# Altium schematic sheet Control_Board.SchDoc
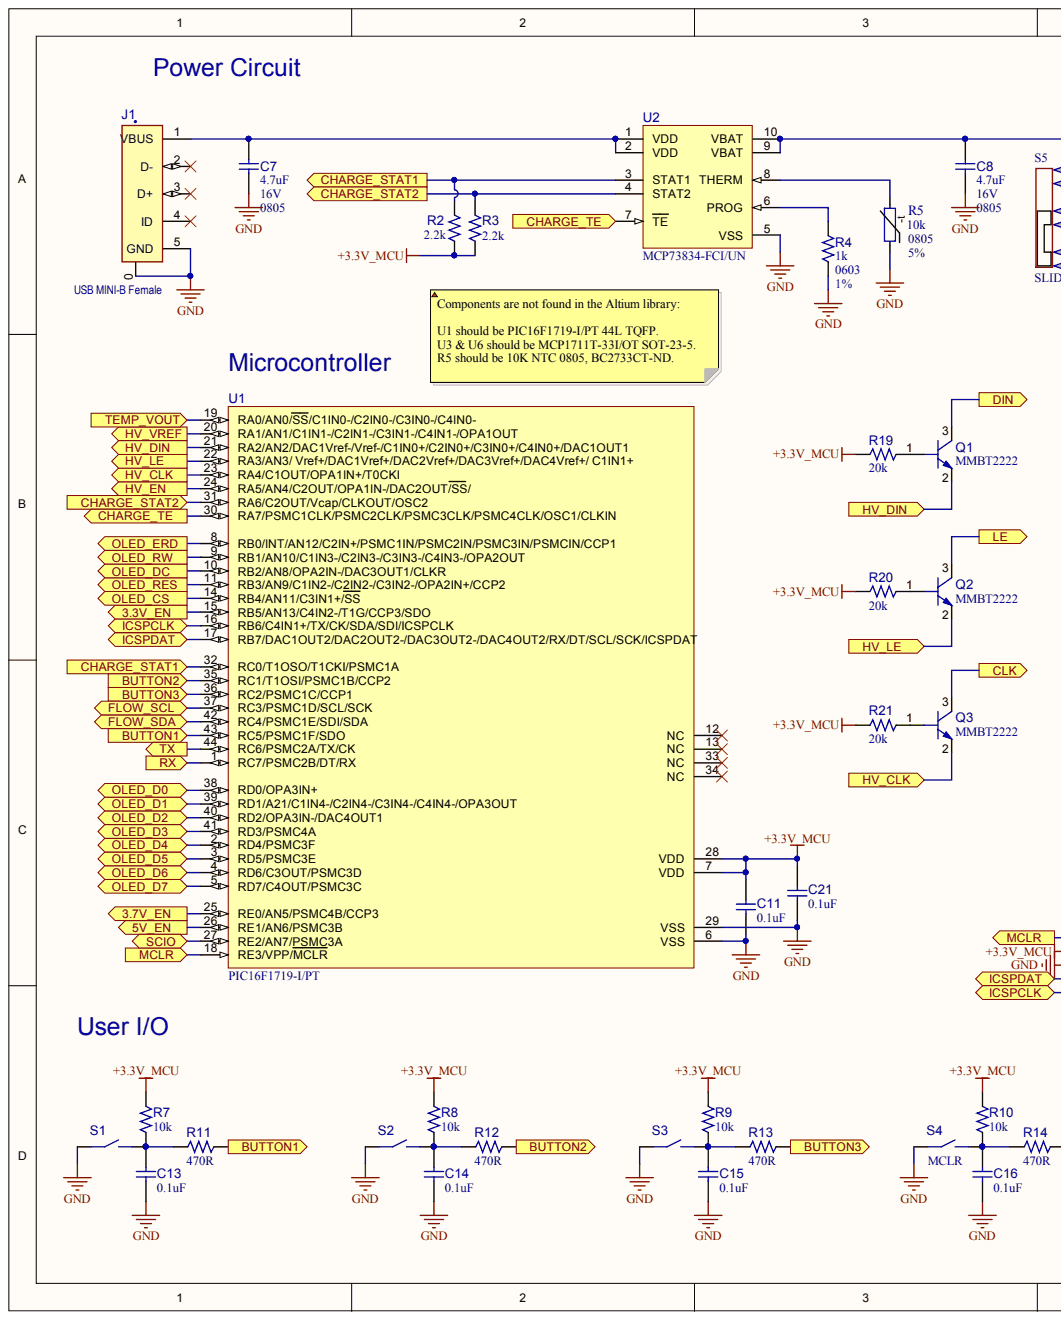
[diagram p0: Control_Board.SchDoc, left]
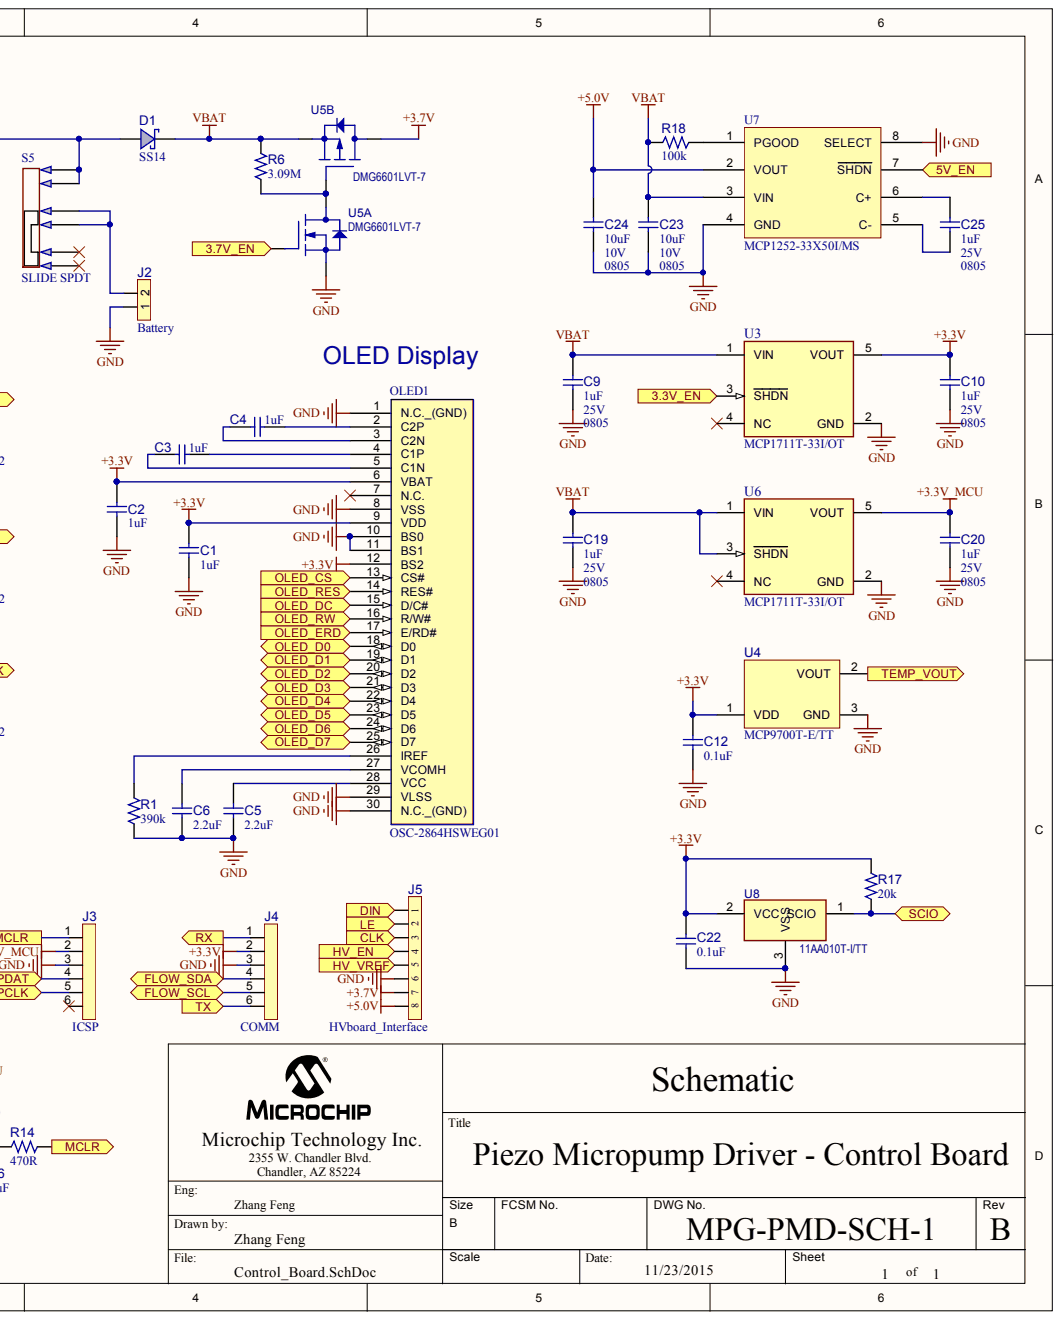
[diagram p1: Control_Board.SchDoc, right]

## Parts
C1  value 1uF  [CAP_C_0603]  (hidden comment: Capacitor NP)
C2  value 1uF  [CAP_C_0603]  (hidden comment: Capacitor NP)
C3  value 1uF  [CAP_C_0603]  (hidden comment: Capacitor NP)
C4  value 1uF  [CAP_C_0603]  (hidden comment: Capacitor NP)
C5  value 2.2uF  [CAP_C_0603]  (hidden comment: Capacitor NP)
C6  value 2.2uF  [CAP_C_0603]  (hidden comment: Capacitor NP)
C7  value 4.7uF  Package: 0805  Voltage: 16V  [CAP_C_0805]  (hidden comment: Capacitor NP)
C8  value 4.7uF  Package: 0805  Voltage: 16V  [CAP_C_0805]  (hidden comment: Capacitor NP)
C9  value 1uF  Package: 0805  Voltage: 25V  [CAP_C_0805]  (hidden comment: Capacitor NP)
C10  value 1uF  Package: 0805  Voltage: 25V  [CAP_C_0805]  (hidden comment: Capacitor NP)
C11  value 0.1uF  [CAP_C_0603]  (hidden comment: Capacitor NP)
C12  value 0.1uF  [CAP_C_0603]  (hidden comment: Capacitor NP)
C13  value 0.1uF  [CAP_C_0603]  (hidden comment: Capacitor NP)
C14  value 0.1uF  [CAP_C_0603]  (hidden comment: Capacitor NP)
C15  value 0.1uF  [CAP_C_0603]  (hidden comment: Capacitor NP)
C16  value 0.1uF  [CAP_C_0603]  (hidden comment: Capacitor NP)
C19  value 1uF  Package: 0805  Voltage: 25V  [CAP_C_0805]  (hidden comment: Capacitor NP)
C20  value 1uF  Package: 0805  Voltage: 25V  [CAP_C_0805]  (hidden comment: Capacitor NP)
C21  value 0.1uF  [CAP_C_0603]  (hidden comment: Capacitor NP)
C22  value 0.1uF  [CAP_C_0603]  (hidden comment: Capacitor NP)
C23  value 10uF  Package: 0805  Voltage: 10V  [CAP_C_0805]  (hidden comment: Capacitor NP)
C24  value 10uF  Package: 0805  Voltage: 10V  [CAP_C_0805]  (hidden comment: Capacitor NP)
C25  value 1uF  Package: 0805  Voltage: 25V  [CAP_C_0805]  (hidden comment: Capacitor NP)
D1  value SS14  Manufacturer Part Number 1: SS14  [DO-214AC_SMA]  (hidden comment: Diode Schottky)
J1  value USB MINI-B Female  Type: USB  [CON_USB-B_Mini_SMD_RA_HIR_UX60-MB-5ST]  (hidden comment: USB_mini_B)
J2  Battery  [HDR_M_2_1X2_SMD_RA]
J3  ICSP  [HDR_M_2.54_1x6]
J4  COMM  [HDR_M_2.54_1x6]
J5  HVboard_Interface  [HDR_M_2.54_1x8_RA]
OLED1  value OSC-2864HSWEG01  Category: Display  Manufacturer Part Number 1: OSC-2864HSWEG01  Type: OLED  [OLED_2864HSWEG01]  (hidden comment: OLED_2864HSWEG01)
Q1  value MMBT2222  Manufacturer Part Number 1: MMBT2222  [SOT-23-3]  (hidden comment: Transistor NPN BEC)
Q2  value MMBT2222  Manufacturer Part Number 1: MMBT2222  [SOT-23-3]  (hidden comment: Transistor NPN BEC)
Q3  value MMBT2222  Manufacturer Part Number 1: MMBT2222  [SOT-23-3]  (hidden comment: Transistor NPN BEC)
R1  value 390k  [RES_C_0603]  (hidden comment: Resistor)
R2  value 2.2k  [RES_C_0603]  (hidden comment: Resistor)
R3  value 2.2k  [RES_C_0603]  (hidden comment: Resistor)
R4  value 1k  Package: 0603  Tolerance: 1%  [RES_C_0603]  (hidden comment: Resistor)
R5  10k  Package: 0805  Tolerance: 5%  [RES_C_0805]
R6  value 3.09M  [RES_C_0603]  (hidden comment: Resistor)
R7  value 10k  [RES_C_0603]  (hidden comment: Resistor)
R8  value 10k  [RES_C_0603]  (hidden comment: Resistor)
R9  value 10k  [RES_C_0603]  (hidden comment: Resistor)
R10  value 10k  [RES_C_0603]  (hidden comment: Resistor)
R11  value 470R  [RES_C_0603]  (hidden comment: Resistor)
R12  value 470R  [RES_C_0603]  (hidden comment: Resistor)
R13  value 470R  [RES_C_0603]  (hidden comment: Resistor)
R14  value 470R  [RES_C_0603]  (hidden comment: Resistor)
R17  value 20k  [RES_C_0603]  (hidden comment: Resistor)
R18  value 100k  [RES_C_0603]  (hidden comment: Resistor)
R19  value 20k  [RES_C_0603]  (hidden comment: Resistor)
R20  value 20k  [RES_C_0603]  (hidden comment: Resistor)
R21  value 20k  [RES_C_0603]  (hidden comment: Resistor)
S1  Switch 2 Pin  [SW_TACT_SMD_CK_KSR231GLFS]  (value not drawn)
S2  Switch 2 Pin  [SW_TACT_SMD_CK_KSR231GLFS]  (value not drawn)
S3  Switch 2 Pin  [SW_TACT_SMD_CK_KSR231GLFS]  (value not drawn)
S4  MCLR  [SW_TACT_SMD_CK_KSR231GLFS]
S5  value SLIDE SPDT  [SW_SLIDE_TH_ESW_EG1271]  (hidden comment: Switch 6 Pin SPDT)
U1  PIC16F1719-I/PT  [TQFP-44_10x10]
U2  MCP73834  Supplier Part Number 1: MCP73834-FCI/UN  [MSOP-10]
U3  MCP1711T-33I/OT  [SOT-23-5]
U4  MCP9700  Manufacturer Part Number 1: MCP9700T-E/TT  [SOT-23-3]
U5  Manufacturer Part Number 1: DMG6601LVT-7  [TSOT26]  (hidden comment: MOSFET N/P-CH 30V 26TSOT)  (parts A,B)
U6  MCP1711T-33I/OT  [SOT-23-5]
U7  MCP1252  Manufacturer Part Number 1: MCP1252-33X50I/MS  [MSOP-8]
U8  11AA010  Manufacturer Part Number 1: 11AA010T-I/TT  [SOT-23-3]

## Ports
3.3V_EN, 3.7V_EN, 5V_EN, BUTTON1, BUTTON2, BUTTON3, CHARGE_STAT1, CHARGE_STAT2, CHARGE_TE, CLK, DIN, FLOW_SCL, FLOW_SDA, HV_CLK, HV_DIN, HV_EN, HV_LE, HV_VREF, ICSPCLK, ICSPDAT, LE, MCLR, OLED_CS, OLED_D0, OLED_D1, OLED_D2, OLED_D3, OLED_D4, OLED_D5, OLED_D6, OLED_D7, OLED_DC, OLED_ERD, OLED_RES, OLED_RW, RX, SCIO, TEMP_VOUT, TX

## Nets
+3.3V: C1.2 C2.2 C10.2 C12.2 C22.2 J4.2 OLED1.6(VBAT) OLED1.9(VDD) OLED1.12(BS2) R17.2 U3.5(VOUT) U4.1 U8.2
+3.3V_MCU: C11.2 C20.2 C21.2 J3.2 R2.1 R3.1 R7.2 R8.2 R9.2 R10.2 R19.1 R20.1 R21.1 U1.7(VDD) U1.28(VDD) U6.5(VOUT)
+3.7V: J5.7 U5.4
+5.0V: C24.2 J5.8 U7.2(VOUT)
GND: C1.1 C2.1 C5.1 C6.1 C7.1 C8.1 C9.1 C10.1 C11.1 C12.1 C13.1 C14.1 C15.1 C16.1 C19.1 C20.1 C21.1 C22.1 C23.1 C24.1 J1.0 J1.5(GND) J2.1 J3.3 J4.3 J5.6 OLED1.1(N.C._(GND)) OLED1.8(VSS) OLED1.10(BS0) OLED1.11(BS1) OLED1.29(VLSS) OLED1.30(N.C._(GND)) R1.1 R4.1 R5.1 S1.1 S2.1 S3.1 S4.1 U1.6(VSS) U1.29(VSS) U2.5(VSS) U3.2(GND) U4.3 U5.5 U6.2(GND) U7.4(GND) U7.8(SELECT) U8.3
VBAT: C9.2 C19.2 C23.2 D1.2 R6.2 R18.1 U3.1(VIN) U5.2 U6.1(VIN) U6.3(SHDN) U7.3(VIN)
3.3V_EN: U1.15(RB5/AN13/C4IN2-/T1G/CCP3/SDO) U3.3(SHDN) ; port 3.3V_EN
3.7V_EN: U1.25(RE0/AN5/PSMC4B/CCP3) U5.1 ; port 3.7V_EN
5V_EN: U1.26(RE1/AN6/PSMC3B) U7.7(SHDN) ; port 5V_EN
BUTTON1: R11.2 U1.43(RC5/PSMC1F/SDO) ; port BUTTON1
BUTTON2: R12.2 U1.35(RC1/T1OSI/PSMC1B/CCP2) ; port BUTTON2
BUTTON3: R13.2 U1.36(RC2/PSMC1C/CCP1) ; port BUTTON3
CHARGE_STAT1: R2.2 U1.32(RC0/T1OSO/T1CKI/PSMC1A) U2.3(STAT1) ; port CHARGE_STAT1
CHARGE_STAT2: R3.2 U1.31(RA6/C2OUT/Vcap/CLKOUT/OSC2) U2.4(STAT2) ; port CHARGE_STAT2
CHARGE_TE: U1.30(RA7/PSMC1CLK/PSMC2CLK/PSMC3CLK/PSMC4CLK/OSC1/CLKIN) U2.7(TE) ; port CHARGE_TE
CLK: J5.3 Q3.3 ; port CLK
DIN: J5.1 Q1.3 ; port DIN
FLOW_SCL: J4.5 U1.37(RC3/PSMC1D/SCL/SCK) ; port FLOW_SCL
FLOW_SDA: J4.4 U1.42(RC4/PSMC1E/SDI/SDA) ; port FLOW_SDA
HV_CLK: Q3.2 U1.23(RA4/C1OUT/OPA1IN+/T0CKI) ; port HV_CLK
HV_DIN: Q1.2 U1.21(RA2/AN2/DAC1Vref-/Vref-/C1IN0+/C2IN0+/C3IN0+/C4IN0+/DAC1OUT1) ; port HV_DIN
HV_EN: J5.4 U1.24(RA5/AN4/C2OUT/OPA1IN-/DAC2OUT/SS/) ; port HV_EN
HV_LE: Q2.2 U1.22(RA3/AN3/_Vref+/DAC1Vref+/DAC2Vref+/DAC3Vref+/DAC4Vref+/_C1IN1+) ; port HV_LE
HV_VREF: J5.5 U1.20(RA1/AN1/C1IN1-/C2IN1-/C3IN1-/C4IN1-/OPA1OUT) ; port HV_VREF
ICSPCLK: J3.5 U1.16(RB6/C4IN1+/TX/CK/SDA/SDI/ICSPCLK) ; port ICSPCLK
ICSPDAT: J3.4 U1.17(RB7/DAC1OUT2/DAC2OUT2-/DAC3OUT2-/DAC4OUT2/RX/DT/SCL/SCK/ICSPDAT) ; port ICSPDAT
LE: J5.2 Q2.3 ; port LE
MCLR: J3.1 R14.2 U1.18(RE3/VPP/MCLR) ; port MCLR
OLED_CS: OLED1.13(CS#) U1.14(RB4/AN11/C3IN1+/SS) ; port OLED_CS
OLED_D0: OLED1.18(D0) U1.38(RD0/OPA3IN+) ; port OLED_D0
OLED_D1: OLED1.19(D1) U1.39(RD1/A21/C1IN4-/C2IN4-/C3IN4-/C4IN4-/OPA3OUT) ; port OLED_D1
OLED_D2: OLED1.20(D2) U1.40(RD2/OPA3IN-/DAC4OUT1) ; port OLED_D2
OLED_D3: OLED1.21(D3) U1.41(RD3/PSMC4A) ; port OLED_D3
OLED_D4: OLED1.22(D4) U1.2(RD4/PSMC3F) ; port OLED_D4
OLED_D5: OLED1.23(D5) U1.3(RD5/PSMC3E) ; port OLED_D5
OLED_D6: OLED1.24(D6) U1.4(RD6/C3OUT/PSMC3D) ; port OLED_D6
OLED_D7: OLED1.25(D7) U1.5(RD7/C4OUT/PSMC3C) ; port OLED_D7
OLED_DC: OLED1.15(D/C#) U1.10(RB2/AN8/OPA2IN-/DAC3OUT1/CLKR) ; port OLED_DC
OLED_ERD: OLED1.17(E/RD#) U1.8(RB0/INT/AN12/C2IN+/PSMC1IN/PSMC2IN/PSMC3IN/PSMCIN/CCP1) ; port OLED_ERD
OLED_RES: OLED1.14(RES#) U1.11(RB3/AN9/C1IN2-/C2IN2-/C3IN2-/OPA2IN+/CCP2) ; port OLED_RES
OLED_RW: OLED1.16(R/W#) U1.9(RB1/AN10/C1IN3-/C2IN3-/C3IN3-/C4IN3-/OPA2OUT) ; port OLED_RW
RX: J4.1 U1.1(RC7/PSMC2B/DT/RX) ; port RX
SCIO: R17.1 U1.27(RE2/AN7/PSMC3A) U8.1 ; port SCIO
TEMP_VOUT: U1.19(RA0/AN0/SS/C1IN0-/C2IN0-/C3IN0-/C4IN0-) U4.2 ; port TEMP_VOUT
TX: J4.6 U1.44(RC6/PSMC2A/TX/CK) ; port TX
NetC3_1: C3.1 OLED1.5(C1N)
NetC3_2: C3.2 OLED1.4(C1P)
NetC4_1: C4.1 OLED1.3(C2N)
NetC4_2: C4.2 OLED1.2(C2P)
NetC5_2: C5.2 OLED1.28(VCC)
NetC6_2: C6.2 OLED1.27(VCOMH)
NetC7_2: C7.2 J1.1(VBUS) U2.1(VDD) U2.2(VDD)
NetC8_2: C8.2 D1.1 S5.3 S5.6 U2.9(VBAT) U2.10(VBAT)
NetC13_2: C13.2 R7.1 R11.1 S1.2
NetC14_2: C14.2 R8.1 R12.1 S2.2
NetC15_2: C15.2 R9.1 R13.1 S3.2
NetC16_2: C16.2 R10.1 R14.1 S4.2
NetC25_1: C25.1 U7.5(C-)
NetC25_2: C25.2 U7.6(C+)
NetJ2_2: J2.2 S5.2 S5.5
NetOLED1_26: OLED1.26(IREF) R1.2
NetQ1_1: Q1.1 R19.2
NetQ2_1: Q2.1 R20.2
NetQ3_1: Q3.1 R21.2
NetR4_2: R4.2 U2.6(PROG)
NetR5_2: R5.2 U2.8(THERM)
NetR6_1: R6.1 U5.3 U5.6
NetR18_2: R18.2 U7.1(PGOOD)

Not connected: J1 2(D-) 3(D+) 4(ID); J3 6; OLED1 7(N.C.); S5 1 4; U1 12(NC) 13(NC) 33(NC) 34(NC); U3 4(NC); U6 4(NC)

## Library data of the parts
C1-C4 = CAP0517: Capacitor; Manufacturer 1: Taiyo Yuden; Manufacturer Part Number 1: EMK107B7105KA-T; Description_: CAP CER 1uF 16V 10% X7R SMD 0603; Populated: Yes; Temperature Coeffcient: X7R; Tolerance: 10%; Type: Ceramic; Voltage: 16V; Category: Capacitor; Component Kind: Standard; ComponentLink1Description: Datasheet; ComponentLink1URL: https://chn-vm-apps.microchip.com/mchprepo/parts/Datasheets/mlcc01_e.pdf; Database Table Name: Capacitor; Library Name: MCHP-CDB.DbLib; Manufacturer 2: TDK; Manufacturer Part Number 2: C1608X7R1C105K; MCHP-ID: CAP0517; Operating Temperature: -55C to +125C; Package: 0603; Quantity Override: 1; Revision: 1; Status: Production; Supplier 1: Digi-Key; Supplier 2: Digi-Key; Supplier Part Number 1: 587-1241-1-ND; Supplier Part Number 2: 445-1604-1-ND
C5 C6 = CAP0597: Capacitor; Manufacturer 1: TDK; Manufacturer Part Number 1: C1608X5R1C225K; Description_: CAP CER 2.2uF 16V 10% X5R SMD 0603; Populated: Yes; Temperature Coeffcient: X5R; Tolerance: 10%; Type: Ceramic; Voltage: 16V; Category: Capacitor; Component Kind: Standard; ComponentLink1Description: Datasheet; ComponentLink1URL: https://chn-vm-apps.microchip.com/mchprepo/parts/Datasheets/general_B11.pdf; Database Table Name: Capacitor; Library Name: MCHP-CDB.DbLib; MCHP-ID: CAP0597; Operating Temperature: -55C to +85C; Package: 0603; Quantity Override: 1; Revision: 2; Status: Production; Supplier 1: Digi-Key; Supplier Part Number 1: 445-5157-1-ND
C7 C8 = CAP0419: Capacitor; Manufacturer 1: Panasonic; Manufacturer Part Number 1: ECJ-2FF1C475Z; Description_: CAP CER 4.7uF 16V 20% Y5V SMD 0805; Populated: Yes; Temperature Coeffcient: Y5V; Tolerance: 20%; Type: Ceramic; Category: Capacitor; Component Kind: Standard; ComponentLink1Description: Datasheet; ComponentLink1URL: https://chn-vm-apps.microchip.com/mchprepo/parts/Datasheets/ECJ__General_.pdf; Database Table Name: Capacitor; Library Name: MCHP-CDB.DbLib; MCHP-ID: CAP0419; Operating Temperature: -30C to +85C; Quantity Override: 1; Revision: 1; Status: Production; Supplier 1: Digi-Key; Supplier Part Number 1: PCC2232TR-ND
C9 C10 C19 C20 C25 = CAP1211: Capacitor; Manufacturer 1: AVX; Manufacturer Part Number 1: 08053C105K4Z2A; Description_: CAP CER 1uF 25V 10% X7R SMD 0805; Owner: Tad Ahlvers; Populated: YES; Temperature Coeffcient: X7R; Tolerance: 10%; Type: Ceramic; Category: Capacitor; Component Kind: Standard; ComponentLink1Description: Datasheet; ComponentLink1URL: https://chn-vm-apps.microchip.com/mchprepo/parts/Datasheets/flexiterm.pdf; Database Table Name: Capacitor; Library Name: MCHP-CDB.DbLib; Manufacturer 2: KEMET; Manufacturer 3: TDK Corporation; Manufacturer Part Number 2: C0805C105K3RACTU; Manufacturer Part Number 3: C2012X7R1E105K125AB; MCHP-ID: CAP1211; Operating Temperature: -55C to +125C; Quantity Override: 1; Revision: 1; Status: MCL Design; Supplier 1: Digi-Key; Supplier 2: Digi-Key; Supplier 3: Digi-Key; Supplier Part Number 1: 478-6357-2-ND; Supplier Part Number 2: C0805C105K3RACTU-ND; Supplier Part Number 3: 445-1354-1-ND
C11-C16 C21 C22 = CAP0011: Capacitor; Manufacturer 1: AVX; Manufacturer Part Number 1: 0603YC104KAT2A; Description_: CAP CER 0.1uF 16V 10% X7R SMD 0603; Populated: Yes; Temperature Coeffcient: X7R; Tolerance: 10%; Type: Ceramic; Voltage: 16V; Category: Capacitor; Component Kind: Standard; ComponentLink1Description: Datasheet; ComponentLink1URL: https://chn-vm-apps.microchip.com/mchprepo/parts/Datasheets/nmc2.pdf; Database Table Name: Capacitor; Library Name: MCHP-CDB.DbLib; Manufacturer 2: Murata; Manufacturer 3: Panasonic; Manufacturer 4: Taiyo Yuden; Manufacturer 5: Yageo; Manufacturer 6: NIC Components; Manufacturer Part Number 2: GRM188R71C104KA01D; Manufacturer Part Number 3: ECJ-1VB1C104K; Manufacturer Part Number 4: EMK107B7104KA-T; Manufacturer Part Number 5: CC0603KRX7R7BB104; Manufacturer Part Number 6: NMC0603X7R104K16TRPF; MCHP-ID: CAP0011; Operating Temperature: -55C to +125C; Package: 0603; Quantity Override: 1; Revision: 3; Status: Production; Supplier 1: Digi-Key; Supplier 2: Digi-Key; Supplier 3: Digi-Key; Supplier 4: Digi-Key; Supplier 5: Newark; Supplier 6: Digi-Key; Supplier 7: NIC Components; Supplier Part Number 1: 478-1239-1-ND; Supplier Part Number 2: 490-1532-1-ND; Supplier Part Number 3: PCC1762CT-ND; Supplier Part Number 4: 587-1240-2-ND; Supplier Part Number 5: 86H5248; Supplier Part Number 6: 311-1088-1-ND; Supplier Part Number 7: NMC0603X7R104K16TRPF
C23 C24 = CAP1148: Capacitor; Manufacturer 1: TDK Corporation; Manufacturer Part Number 1: C2012X7R1A106K125AC; Description_: CAP CER 10uF 10V 10% X7R SMD 0805; Dev Tools Status: Production; Owner: Mihai T(M91442); Populated: Yes; Temperature Coeffcient: X7R; Tolerance: 10%; Type: Ceramic; Category: Capacitor; Component Kind: Standard; ComponentLink1Description: Datasheet; ComponentLink1URL: https://chn-vm-apps.microchip.com/mchprepo/parts/Datasheets/L0005E.pdf; Database Table Name: Capacitor; Library Name: MCHP-CDB.DbLib; Manufacturer 2: Murata; Manufacturer Part Number 2: GRM21BR71A106KE51L; MCHP-ID: CAP1148; Operating Temperature: -55C to +125C; Quantity Override: 1; Revision: 0; Status: MCL Design; Supplier 1: Digi-Key; Supplier 2: Digi-Key; Supplier 3: Farnell; Supplier Part Number 1: 445-6857-1-ND; Supplier Part Number 2: 490-3905-1-ND; Supplier Part Number 3: 1828828
D1 = DIODE0128: Manufacturer 1: Fairchild; Description_: DIO SCTKY SS14 500mV 1A 40V DO-214AC_SMA; Forward Current: 1A; Populated: YES; Power: 1.1W; Reverse Current: 200uA; Reverse Voltage: 40V; Type: Schottky Single; Category: Diode; Component Kind: Standard; ComponentLink1Description: Datasheet; ComponentLink1URL: https://chn-vm-apps.microchip.com/mchprepo/parts/Datasheets/SS12.pdf; Database Table Name: Diode; Library Name: MCHP-CDB.DbLib; MCHP-ID: DIODE0128; Operating Temperature: -65C to +125C; Package: DO-214AC_SMA; Quantity Override: 1; Revision: 1; Status: Production; Supplier 1: Digi-Key; Supplier Part Number 1: SS14CT-ND
J1 = CON0276: Connector USB; Manufacturer 1: Hirose; Manufacturer Part Number 1: UX60-MB-5ST; Description_: CON USB MINI-B Female SMD R/A; Populated: Yes; Category: Connector; Component Kind: Standard; ComponentLink1Description: Datasheet; ComponentLink1URL: https://chn-vm-apps.microchip.com/mchprepo/parts/Datasheets/e24000019.pdf; Database Table Name: Connector; Library Name: MCHP-CDB.DbLib; Manufacturer 2: Oupiin; Manufacturer Part Number 2: 8969-B05C00DBU; MCHP-ID: CON0276; Quantity Override: 1; Revision: 2; Status: Production; Supplier 1: Digi-Key; Supplier 2: Digi-Key; Supplier 3: Oupiin; Supplier Part Number 1: H2959CT-ND; Supplier Part Number 2: H2959TR-ND; Supplier Part Number 3: 8969-B05C00DBU
J2 = CON1434: Manufacturer 1: JST Sales America Inc.; Manufacturer Part Number 1: S2B-PH-SM4-TB(LF)(SN); Database Table Name: Connector; Description_: CONN HDR-2 Male 1X2 SMD R/A; Dev Tools Status: Design; Owner: Jose Garcia; Populated: YES; Value: CONN HDR PH SMD 2MM; Category: Connector; Component Kind: Standard; ComponentLink1Description: Datasheet; ComponentLink1URL: https://chn-vm-apps.microchip.com/mchprepo/parts/Datasheets/ePH; Library Name: MCHP-CDB.DbLib; MCHP-ID: CON1434; Quantity Override: 1; Revision: 1; Status: MCL Design; Supplier 1: Digi-Key; Supplier Part Number 1: 455-1749-1-ND; Type: Header 2mm
J3 J4 = CON1106: Manufacturer 1: FCI; Manufacturer Part Number 1: 68001-106HLF; Database Table Name: Connector; Description_: CON HDR-2.54 Male 1x6 Gold 5.84MH TH VERT; Owner: Bogdan P (M91398); Populated: Yes; Value: HDR-2.54 Male 1x6; Category: Connector; Component Kind: Standard; ComponentLink1Description: Datasheet; ComponentLink1URL: https://chn-vm-apps.microchip.com/mchprepo/parts/Datasheets/68000.pdf; Library Name: MCHP-CDB.DbLib; MCHP-ID: CON1106; Quantity Override: 1; Revision: 0; Status: Production; Supplier 1: Digi-Key; Supplier Part Number 1: 609-3272-ND; Type: Header 2.54mm
J5 = CON1290: Manufacturer 1: Sullins Connector Solutions; Manufacturer Part Number 1: PBC08SBAN; Database Table Name: Connector; Description_: CON HDR-2.54 Male 1x8 Gold 5.84MH TH R/A; Owner: Bogdan Popescu; Populated: YES; Value: HDR-2.54 Male 1x8; Category: Connector; Component Kind: Standard; ComponentLink1Description: Datasheet; ComponentLink1URL: https://chn-vm-apps.microchip.com/mchprepo/parts/Datasheets/97_Page78-79_HEADER_.100_2.54mm_MALE_BREAKAWAY.pdf; Library Name: MCHP-CDB.DbLib; MCHP-ID: CON1290; Quantity Override: 1; Revision: A; Status: Design; Supplier 1: Digi-Key; Supplier Part Number 1: S1111E-08-ND; Type: Header 2.54mm
OLED1 = LCD0034: Manufacturer 1: OSD Displays; Description_: DISPLAY OLED OSC-2864HSWEG01 MNT; Owner: Mike Sullivan (Arena); Populated: YES; Component Kind: Standard; ComponentLink1Description: Datasheet; ComponentLink1URL: https://chn-vm-apps.microchip.com/mchprepo/parts/Datasheets/OSD-2864HSWEG01.pdf; Database Table Name: Display; Library Name: MCHP-CDB.DbLib; MCHP-ID: LCD0034; Operating Temperature: -30C to +70C; Quantity Override: 1; Revision: 0; Status: Design; Supplier 1: OSD Displays; Supplier Part Number 1: OSC-2864HSWEG01
Q1-Q3 = TRA0039: Transistor Bipolar; Manufacturer 1: Fairchild; Current: 600mA; Description_: TRANS BJT NPN MMBT2222 30V 600mA 350mW SOT-23-3; Dev Tools Status: Production; Populated: YES; Power: 350mW; Type: BJT; Voltage: 30V; Category: Transistor; Component Kind: Standard; ComponentLink1Description: Datasheet; ComponentLink1URL: https://chn-vm-apps.microchip.com/mchprepo/parts/Datasheets/MMBT2222.pdf; Database Table Name: Transistor; Library Name: MCHP-CDB.DbLib; MCHP-ID: TRA0039; Operating Temperature: -55C to +150C; Package: SOT-23-3; Quantity Override: 1; Revision: 2; Status: MCL Design; Supplier 1: Fairchild; Supplier Part Number 1: MMBT2222
R1 = RSMT0758: Manufacturer 1: Panasonic; Manufacturer Part Number 1: ERJ-3EKF3903V; Description_: RES TKF 390k 1% 1/10W SMD 0603; Populated: Yes; Power: 1/10W; Temperature Coeffcient: 100ppm/C; Tolerance: 1%; Voltage: 75V; Category: Resistor; Component Kind: Standard; ComponentLink1Description: Datasheet; ComponentLink1URL: https://chn-vm-apps.microchip.com/mchprepo/parts/Datasheets/AOA0000CE2.pdf; Composition: TKF; Database Table Name: Resistor; Description: Resistor; Library Name: MCHP-CDB.DbLib; MCHP-ID: RSMT0758; Operating Temperature: -55C to +155C; Package: 0603; Quantity Override: 1; Revision: 1; Status: Production; Supplier 1: Digi-Key; Supplier Part Number 1: P390KHCT-ND; Type: RES SMD
R2 R3 = RES1130: Manufacturer 1: Panasonic; Manufacturer Part Number 1: ERJ-3EKF2201V; Description_: RES TKF 2.2k 1% 1/10W SMD 0603; Owner: Bogdan P (M91398); Populated: Yes; Power: 1/10W; Temperature Coeffcient: 100ppm/C; Tolerance: 1%; Voltage: 75V; Category: Resistor; Component Kind: Standard; ComponentLink1Description: Datasheet; ComponentLink1URL: https://chn-vm-apps.microchip.com/mchprepo/parts/Datasheets/AOA0000CE2.pdf; Composition: TKF; Database Table Name: Resistor; Description: Resistor; Library Name: MCHP-CDB.DbLib; MCHP-ID: RES1130; Operating Temperature: -55C to +155C; Package: 0603; Quantity Override: 1; Revision: 0; Status: Production; Supplier 1: Digi-Key; Supplier Part Number 1: P2.20KHCT-ND; Type: RES SMD
R4 = RSMT0138: Manufacturer 1: Panasonic; Manufacturer Part Number 1: ERJ-3EKF1001V; Description_: RES TKF 1k 1% 1/10W SMD 0603; Populated: Yes; Power: 1/10W; Temperature Coeffcient: 100ppm/C; Voltage: 75V; Category: Resistor; Component Kind: Standard; ComponentLink1Description: Datasheet; ComponentLink1URL: https://chn-vm-apps.microchip.com/mchprepo/parts/Datasheets/AOA0000CE2.pdf; Composition: TKF; Database Table Name: Resistor; Description: Resistor; Library Name: MCHP-CDB.DbLib; Manufacturer 2: Yageo; Manufacturer 3: ROHM; Manufacturer 4: Stackpole Electronics Inc; Manufacturer 5: Stackpole Electronics Inc; Manufacturer 6: Vishay; Manufacturer 7: Stackpole Electronics Inc; Manufacturer Part Number 2: RC0603FR-071KL; Manufacturer Part Number 3: MCR03EZPFX1001; Manufacturer Part Number 4: RMCF0603FT1K00; Manufacturer Part Number 5: RMCF 1/16 1K 1% R; Manufacturer Part Number 6: CRCW06031K00FKEA; Manufacturer Part Number 7: RMCF1/161.0K1%TR; MCHP-ID: RSMT0138; Operating Temperature: -55C to +155C; Quantity Override: 1; Revision: 2; Status: Production; Supplier 1: Digi-Key; Supplier 2: Digi-Key; Supplier 3: Digi-Key; Supplier 4: Digi-Key; Supplier 5: Digi-Key; Supplier 6: Digi-Key; Supplier 7: Newark; Supplier 8: Stackpole Electronics Inc; Supplier Part Number 1: P1.00KHCT-ND; Supplier Part Number 2: P1.00KHTR-ND; Supplier Part Number 3: 311-1.00KHRCT-ND; Supplier Part Number 4: RHM1.00KHCT-ND; Supplier Part Number 5: RMCF1/161KFRTR-ND; Supplier Part Number 6: RMCF0603FT1K00TR-ND; Supplier Part Number 7: 52K8015; Supplier Part Number 8: RMCF1/161.0K1%TR; Type: RES SMD
R5 = RES1425: Manufacturer 1: Vishay BC Components; Manufacturer Part Number 1: NTCS0805E3473JHT; Circuit Type: Isolated; Database Table Name: Resistor; Description_: RES THERMISTOR 47K 5% 210mW SMD 0805; Owner: Stephen Humberd; Populated: YES; Power: 210mW; Temperature Coeffcient: NTC; Value: 47k; Category: Resistor; Component Kind: Standard; ComponentLink1Description: Datasheet; ComponentLink1URL: https://chn-vm-apps.microchip.com/mchprepo/parts/Datasheets/ntcs0805e3t.pdf; Composition: C; Library Name: MCHP-CDB.DbLib; MCHP-ID: RES1425; Operating Temperature: -40C to +150C; Quantity Override: 1; Revision: B; Status: Design; Supplier 1: Digi-Key; Supplier Part Number 1: BC2297CT-ND; Type: Thermistor
R6 = RSMT1115: Manufacturer 1: Vishay Dale; Manufacturer Part Number 1: CRCW06033M09FKEA; Description_: RES TKF 3.09M 1% 1/10W SMD 0603; Owner: Bogdan Popescu; Populated: YES; Power: 1/10W; Temperature Coeffcient: 100ppm/C; Tolerance: 1%; Voltage: 75V; Category: Resistor; Component Kind: Standard; ComponentLink1Description: Datasheet; ComponentLink1URL: https://chn-vm-apps.microchip.com/mchprepo/parts/Datasheets/dcrcwe3.pdf; Composition: TKF; Database Table Name: Resistor; Description: Resistor; Library Name: MCHP-CDB.DbLib; MCHP-ID: RSMT1115; Operating Temperature: -55C to +155C; Package: 0603; Quantity Override: 1; Revision: A; Status: Design; Supplier 1: Digi-Key; Supplier Part Number 1: 541-3.09MHCT-ND; Type: RES SMD
R7-R10 = RSMT0139: Manufacturer 1: Panasonic; Manufacturer Part Number 1: ERJ-3EKF1002V; Description_: RES TKF 10k 1% 1/10W SMD 0603; Populated: Yes; Power: 1/10W; Temperature Coeffcient: 100ppm/C; Tolerance: 1%; Category: Resistor; Component Kind: Standard; ComponentLink1Description: Datasheet; ComponentLink1URL: https://chn-vm-apps.microchip.com/mchprepo/parts/Datasheets/nrc.pdf; Composition: TKF; Database Table Name: Resistor; Description: Resistor; Library Name: MCHP-CDB.DbLib; Manufacturer 2: Stackpole Electronics Inc; Manufacturer 3: Yageo; Manufacturer 4: Stackpole Electronics Inc; Manufacturer 5: Xicon; Manufacturer 6: NIC Components; Manufacturer Part Number 2: RMCF0603FT10K0; Manufacturer Part Number 3: RC0603FR-0710KL; Manufacturer Part Number 4: RMCF 1/16 10K 1% R; Manufacturer Part Number 5: 302-10K-RC; Manufacturer Part Number 6: NRC06F1002TRF; MCHP-ID: RSMT0139; Operating Temperature: -55C to +155C; Package: 0603; Quantity Override: 1; Revision: 2; Status: Production; Supplier 1: Digi-Key; Supplier 2: Digi-Key; Supplier 3: Digi-Key; Supplier 4: Digi-Key; Supplier 5: Mouser; Supplier 6: NIC Components; Supplier Part Number 1: P10.0KHCT-ND; Supplier Part Number 2: RMCF1/1610KFRTR-ND; Supplier Part Number 3: 311-10.0KHRCT-ND; Supplier Part Number 4: RMCF0603FT10K0TR-ND; Supplier Part Number 5: 302-10k-RC; Supplier Part Number 6: NRC06F1002TRF; Type: RES SMD
R11-R14 = RSMT0518: Manufacturer 1: Yageo; Manufacturer Part Number 1: RC0603FR-07470RL; Description_: RES TKF 470R 1% 1/10W SMD 0603; Populated: Yes; Power: 1/10W; Temperature Coeffcient: 100ppm/C; Tolerance: 1%; Voltage: 50V; Category: Resistor; Component Kind: Standard; ComponentLink1Description: Datasheet; ComponentLink1URL: https://chn-vm-apps.microchip.com/mchprepo/parts/Datasheets/PYu-RC0603_51_RoHS_L_4.pdf; Composition: TKF; Database Table Name: Resistor; Description: Resistor; Library Name: MCHP-CDB.DbLib; Manufacturer 2: Panasonic; Manufacturer 3: Stackpole Electronics Inc; Manufacturer Part Number 2: ERJ-3EKF4700V; Manufacturer Part Number 3: RMCF0603FT470R; MCHP-ID: RSMT0518; Operating Temperature: -55C to +155C; Package: 0603; Quantity Override: 1; Revision: 1; Status: Production; Supplier 1: Digi-Key; Supplier 2: Digi-Key; Supplier 3: Digi-Key; Supplier Part Number 1: 311-470HRCT-ND; Supplier Part Number 2: P470HCT-ND; Supplier Part Number 3: RMCF1/16470FRTR-ND; Type: RES SMD
R17 = RSMT0309: Manufacturer 1: Yageo; Manufacturer Part Number 1: 9C06031A2002FKHFT; Description_: RES TKF 20k 1% 1/10W SMD 0603; Populated: Yes; Power: 1/10W; Temperature Coeffcient: 100ppm/C; Tolerance: 1%; Voltage: 50V; Category: Resistor; Component Kind: Standard; ComponentLink1Description: Datasheet; ComponentLink1URL: https://chn-vm-apps.microchip.com/mchprepo/parts/Datasheets/PYu-RC0603_51_RoHS_L_4.pdf; Composition: TKF; Database Table Name: Resistor; Description: Resistor; Library Name: MCHP-CDB.DbLib; Manufacturer 2: Yageo; Manufacturer 3: Yageo; Manufacturer 4: Stackpole Electronics Inc; Manufacturer 5: Panasonic; Manufacturer Part Number 2: RC0603FR-0720KL; Manufacturer Part Number 3: 9C06031A2002FKPFT; Manufacturer Part Number 4: RMCF1/1620.0K1%TR; Manufacturer Part Number 5: ERJ-3EKF2002V; MCHP-ID: RSMT0309; Operating Temperature: -55C to +155C; Package: 0603; Quantity Override: 1; Revision: 1; Status: Production; Supplier 1: Digi-Key; Supplier 2: Yageo; Supplier 3: Digi-Key; Supplier 4: Yageo; Supplier 5: Stackpole Electronics Inc; Supplier 6: Digi-Key; Supplier Part Number 1: 311-20.0KHTR-ND; Supplier Part Number 2: RC0603FR-0720KL; Supplier Part Number 3: 311-20.0KHRCT-ND; Supplier Part Number 4: 9C06031A2002FKPFT; Supplier Part Number 5: RMCF1/1620.0K1%TR; Supplier Part Number 6: P20.0KHCT-ND; Type: RES SMD
R18 = RES1066: Manufacturer 1: Vishay; Manufacturer Part Number 1: MCT06030C1003FP500; Description_: RES TF 100k 1% 1/8W SMD 0603; Owner: Tad Ahlvers; Populated: Yes; Power: 1/8W; Temperature Coeffcient: 50ppm/C; Tolerance: 1%; Voltage: 75V; Category: Resistor; Component Kind: Standard; ComponentLink1Description: Datasheet; ComponentLink1URL: https://chn-vm-apps.microchip.com/mchprepo/parts/Datasheets/mc_pro.pdf; Composition: TF; Database Table Name: Resistor; Description: Resistor; Library Name: MCHP-CDB.DbLib; MCHP-ID: RES1066; Operating Temperature: -55C to +155C; Package: 0603; Quantity Override: 1; Revision: 1; Status: Production; Supplier 1: Digi-Key; Supplier Part Number 1: MCT0603-100K-CFTR-ND; Type: RES SMD
R19-R21 = RSMT0309: Manufacturer 1: Panasonic; Manufacturer Part Number 1: ERJ-3EKF2002V; Description_: RES TKF 20k 1% 1/10W SMD 0603; Dev Tools Status: Production; Populated: Yes; Power: 1/10W; Temperature Coeffcient: 100ppm/C; Tolerance: 1%; Voltage: 50V; Category: Resistor; Component Kind: Standard; ComponentLink1Description: Datasheet; ComponentLink1URL: https://chn-vm-apps.microchip.com/mchprepo/parts/Datasheets/PYu-RC0603_51_RoHS_L_4.pdf; Composition: TKF; Database Table Name: Resistor; Description: Resistor; Library Name: MCHP-CDB.DbLib; Manufacturer 2: Yageo; Manufacturer 3: Yageo; Manufacturer 4: Stackpole Electronics Inc; Manufacturer 5: Yageo; Manufacturer Part Number 2: 9C06031A2002FKHFT; Manufacturer Part Number 3: RC0603FR-0720KL; Manufacturer Part Number 4: RMCF1/1620.0K1%TR; Manufacturer Part Number 5: 9C06031A2002FKPFT; MCHP-ID: RSMT0309; Operating Temperature: -55C to +155C; Package: 0603; Quantity Override: 1; Revision: 1; Status: MCL Design; Supplier 1: Digi-Key; Supplier 2: Digi-Key; Supplier 3: Digi-Key; Supplier 4: Yageo; Supplier 5: Stackpole Electronics Inc; Supplier 6: Yageo; Supplier Part Number 1: P20.0KHCT-ND; Supplier Part Number 2: 311-20.0KHTR-ND; Supplier Part Number 3: 311-20.0KHRCT-ND; Supplier Part Number 4: RC0603FR-0720KL; Supplier Part Number 5: RMCF1/1620.0K1%TR; Supplier Part Number 6: 9C06031A2002FKPFT; Type: RES SMD
S1-S4 = SWITCH1040: Switch; Manufacturer 1: TE Connectivity / Alcoswitch; Manufacturer Part Number 1: 147873-1; Current: 50mA; Description_: SWITCH TACT SPST 24V 50mA 147873-1 SMD; Owner: Stephen Humberd; Populated: YES; Value: 147873-1; Voltage: 24V; Category: Switch; Component Kind: Standard; ComponentLink1Description: Datasheet; ComponentLink1URL: https://chn-vm-apps.microchip.com/mchprepo/parts/Datasheets/NG_CD_147873_K3-196492.pdf; Database Table Name: Switch; Library Name: MCHP-CDB.DbLib; MCHP-ID: SWITCH1040; Quantity Override: 1; Revision: 1; Status: Production; Supplier 1: Mouser; Supplier Part Number 1: 506-147873-1; Type: Pushbutton
S5 = SWITCH0051: Switch, Slide, SPDT, TH; Manufacturer 1: E-Switch; Manufacturer Part Number 1: EG1271; Current: 300mA; Description_: SWITCH SLIDE DPDT 30V 300mA EG1271 TH; Dev Tools Status: Production; Populated: YES; Voltage: 30V; Category: Switch; Component Kind: Standard; ComponentLink1Description: Datasheet; ComponentLink1URL: https://chn-vm-apps.microchip.com/mchprepo/parts/Datasheets/EG.pdf; Database Table Name: Switch; Library Name: MCHP-CDB.DbLib; MCHP-ID: SWITCH0051; Operating Temperature: -20C to +70C; Quantity Override: 1; Revision: 1; Status: MCL Design; Supplier 1: Digi-Key; Supplier Part Number 1: EG1918-ND; Type: Slide
U1 = MIC6241: PIC16F; Manufacturer 1: Microchip Technology; Manufacturer Part Number 1: PIC16F1789-I/PT; ADCBit: 12; ADC Channels: 14; CAN: 0; Comparators: 4; Database Table Name: MCHP-Microcontroller; Description_: MCHP MCU 8-BIT 28K 2K PIC16F1789-I/PT 44TQFP; DigitalTimerQty_8bit: 2; DigitalTimerQty_16bit: 1; EEPROM: 256; ForceMinOrderQtyMult: True; IOPins: 36; LeadContent: Pb-FREE; LeadCount: 44; MAPS Description: 44-pin, 28KB Flash, 2KB RAM, 256 EEPROM, 12-bit DAC, 5-bit DAC, PSMC, OPAmp,; MaxSpeed: 32; MinOrderQty: 160; MPC: LECM17T4XAXX; OscillatorMHz: 32 MHz, 32 kHz; Owner: Jamus Griego; PackageWidth: 10x10x1mm; PackingMedia: TRAY; Populated: YES; Program Memory KBytes: 28; Program Memory KWords: 16; Ram: 2048; Stage: REL; TempRange: -40C to +85C; Value: PIC16F1789-I/PT; CapTouchCH: 14; Category: MCHP-Microcontroller; Component Kind: Standard; ComponentLink1Description: Datasheet; ComponentLink1URL: http://www.microchip.com/wwwproducts/Devices.aspx?product=PIC16F1789; DAChannels: 4; DigitalTimerQty_32bit: 0; Family: Enhanced Mid-Range; I2C: 1; Input Capture: 0; IntroductionDate: 2013-04-04; Library Name: MCHP-CDB.DbLib; MCHP-ID: MIC6241; mtrlcntrlpwmchannels: 14; PackageType: TQFP; PWM Channels: 0; QEI Channels: 0; Quantity Override: 1; Revision: B; SegmentLCD: 0; SMPS PWM Channels: 14; SPI: 1; Status: Design; Supplier 1: Digi-Key; Supplier Part Number 1: PIC16F1789-I/PT-ND; Type: 8-Bit; USART: 1; USBChannels: 0
U2 = MIC3178: MCP738XX; Manufacturer 1: Microchip; Manufacturer Part Number 1: MCP73833-FCI/UN; AutomaticRecharge: Yes; Database Table Name: MCHP-Analog; Description_: MCHP ANALOG BATTERY CHARGER MCP73833T-FCI/UN MSOP-10; ForceMinOrderQtyMult: False; IoutMax: 1200; LeadContent: Pb-FREE; LeadCount: 10; MAPS Description: 1A ADVANCED SINGLE CELL, FULLY INTEGRATED LI-ION POLYMER CHANGE MANAGEMENT CONTR; MinOrderQty: 5; MPC: DECJ27E3XAFC; NumberofLiIonCells: 1; OperatingCurrentTyp: 2; PackageWidth: 3x3mm; PackingMedia: TUBE; PassTransistor: INT; ReverseDischargeCurrentTyp: 0.200000002980232; Stage: REL; tblDeviceFamilyID: 1704; TempRange: -40C to +85C; UVLO: 3.5; Value: MCP73833; VccRangeMax: 6; VccRangeMin: 3.75; VolRegAccuracy: 0.75; VoltageRegulationTyp: 4.2, 4.35, 4.4, 4.5; Category: MCHP-Analog; ChargeMonitor: Yes; ChargeSafetyTimers: Yes; ChargeTermination: Yes; Component Kind: Standard; ComponentLink1Description: Datasheet; ComponentLink1URL: http://www.microchip.com/wwwproducts/Devices.aspx?product=MCP73833; IntroductionDate: 2006-09-05; Library Name: MCHP-CDB.DbLib; Manufacturer 2: Microchip; Manufacturer Part Number 2: MCP73833T-FCI/UN; MaxThermalRegulation: Yes; MCHP-ID: MIC3178; PackageType: MSOP; Populated: Yes; PreconditionCharge: Yes; Quantity Override: 1; Revision: 0; ShutdownMode: Yes; Status: Production; StatusOutput: Yes; Supplier 1: Microchip; Supplier 2: Microchip; Supplier Part Number 2: MCP73833T-FCI/UN; SupplyPresent: Yes; Technology: Linear; TempMonitor: Yes; Type: Battery Charger
U3 U6 = MIC6434: LDO MCP1711; Manufacturer 1: Microchip Technology; Manufacturer Part Number 1: MCP1711T-18I/OT; Accuracy: 1; Adjustable: No; Comments: New device; Database Table Name: MCHP-Analog; Description_: MCHP ANALOG LDO 1.8V MCP1711T-18I/OT SOT-23-5; Dropoutvoltage: 500; ForceMinOrderQtyMult: True; LeadContent: Pb-FREE; LeadCount: 5; MAPS Description: 600 nA Low Quiescent Current LDO; MaxInputVoltage: 6; MinInputVoltage: 1.4; MPC: YZ026TC7XA18; OutputCapType: CER/AL/TANT; OutputCurrent: 150; Owner: Sorin Stanoaia; PackingMedia: T/R; Populated: YES; SingleDual: Single; Stage: REL; tblDeviceFamilyID: 5913; TempRange: -40C to +85C; TypicalActiveCurrent: 0.6; Value: MCP1711/1.8V; VoutMin: 1.2; VOUTtyp: 3.3; Category: MCHP-Analog; Component Kind: Standard; ComponentLink1Description: Datasheet; ComponentLink1URL: http://www.microchip.com/wwwproducts/Devices.aspx?product=MCP1711; IntroductionDate: 2015-05-06; Library Name: MCHP-CDB.DbLib; MCHP-ID: MIC6434; MinOrderQty: 5; PackageType: SOT-23; Quantity Override: 1; ResetOutput: No; Revision: 1; SHDN: Yes; Status: Production; Supplier 1: Digi-Key; Supplier Part Number 1: MCP1711T-18I/OTCT-ND; Type: LDO; VoutMax: 5
U4 = MIC0740: MCP97XX; Manufacturer 1: Microchip; Comments: Low cost; Database Table Name: MCHP-Analog; Description_: MCHP ANALOG TEMPERATURE SENSOR -40C to +150C MCP9700T-E/TT SOT-23-3; ForceMinOrderQtyMult: True; LeadContent: Pb-FREE; LeadCount: 3; MAPS Description: LENEAR ACTIVE THERMISTER 9TM) IC(10MV/OC); MaxAccuracy_25C: 4; MaxSupplyCurrent: 6; MinOrderQty: 5; MPC: GBAT1YC6XA00; Offset: 500; PackingMedia: T/R; Populated: Yes; SensorOutput: Analog; Slope: 10; Stage: REL; tblDeviceFamilyID: 1068; TempRange: -40C to +125C; TypicalAccuracy: 1; VccRangeMax: 5.5; VccRangeMin: 2.3; Category: MCHP-Analog; Component Kind: Standard; ComponentLink1Description: Datasheet; ComponentLink1URL: http://www.microchip.com/wwwproducts/Devices.aspx?product=MCP9700; IntroductionDate: 2007-05-01; Library Name: MCHP-CDB.DbLib; MCHP-ID: MIC0740; PackageType: SOT-23; Quantity Override: 1; Revision: 1; Status: Production; Supplier 1: Microchip; Supplier Part Number 1: MCP9700T-E/TT; Type: Temperature Sensor; Value: MCP9700
U5 = MOSFET N/P-CH 30V: Manufacturer 1: Diodes Inc.; Component Kind: Standard; Description: MOSFET N/P-CH 30V 26TSOT; Description_: MOSFET N/P-CH 30V 26TSOT; Supplier 1: Digi-Key; Supplier Part Number 1: DMG6601LVT-7DICT-ND; Library Name: BBM_Local.SchLib
U7 = MIC6473: Low-Noise, Positive-Regulated Charge Pump; Manufacturer 1: Microchip Technology; Accuracy: 0.5; Adjustable: Yes; ControlPWM: NA; Database Table Name: MCHP-Analog; Description_: MCHP ANALOG CHARGE PUMP 3.3V/5V MCP1252-33X50I/MS MSOP-8; ExternalSync: No; ForceMinOrderQtyMult: False; InputVoltageRangeMax: 5.5; InputVoltageRangeMin: 2.1; LeadContent: Pb-FREE; LeadCount: 8; MAPS Description: Positive regulated charge pump; MinOrderQty: 5; MPC: Y4BD17A3XB00; OutputCapType: Low ESR; OutputCurrent: 120; Owner: Jamus Griego; PackageWidth: 3x3mm; PackingMedia: TUBE; QuiescentCurrent: 80; ResetOutput: PG; SingleDual: Single; Stage: REL; SwitchingFrequency: 650; tblDeviceFamilyID: 222; TempRange: -40C to +85C; Value: MCP1252-33X50; VoutMin: 1.5; Category: MCHP-Analog; Component Kind: Standard; ComponentLink1Description: Datasheet; ComponentLink1URL: http://www.microchip.com/wwwproducts/Devices.aspx?product=MCP1252; IntroductionDate: 2002-11-27; Library Name: MCHP-CDB.DbLib; MCHP-ID: MIC6473; PackageType: MSOP; Populated: YES; Quantity Override: 1; Revision: A; SHDN: Yes; Status: Design; Supplier 1: Digi-Key; Supplier Part Number 1: MCP1252-33X50I/MS-ND; Topology: Regulated; Type: Voltage Regulator; UVLO: No; VoutMax: 5.5
U8 = (no symbol name): IC EEPROM 1KBIT 100KHZ SOT23-3; Manufacturer 1: Microchip; Component Kind: Standard; Revision: 0; Supplier 1: Digi-Key; Supplier Part Number 1: 11AA010T-I/TTCT-ND; Library Name: iBeacon.SchLib
